# Altium schematic sheet Battery Charger.SchDoc
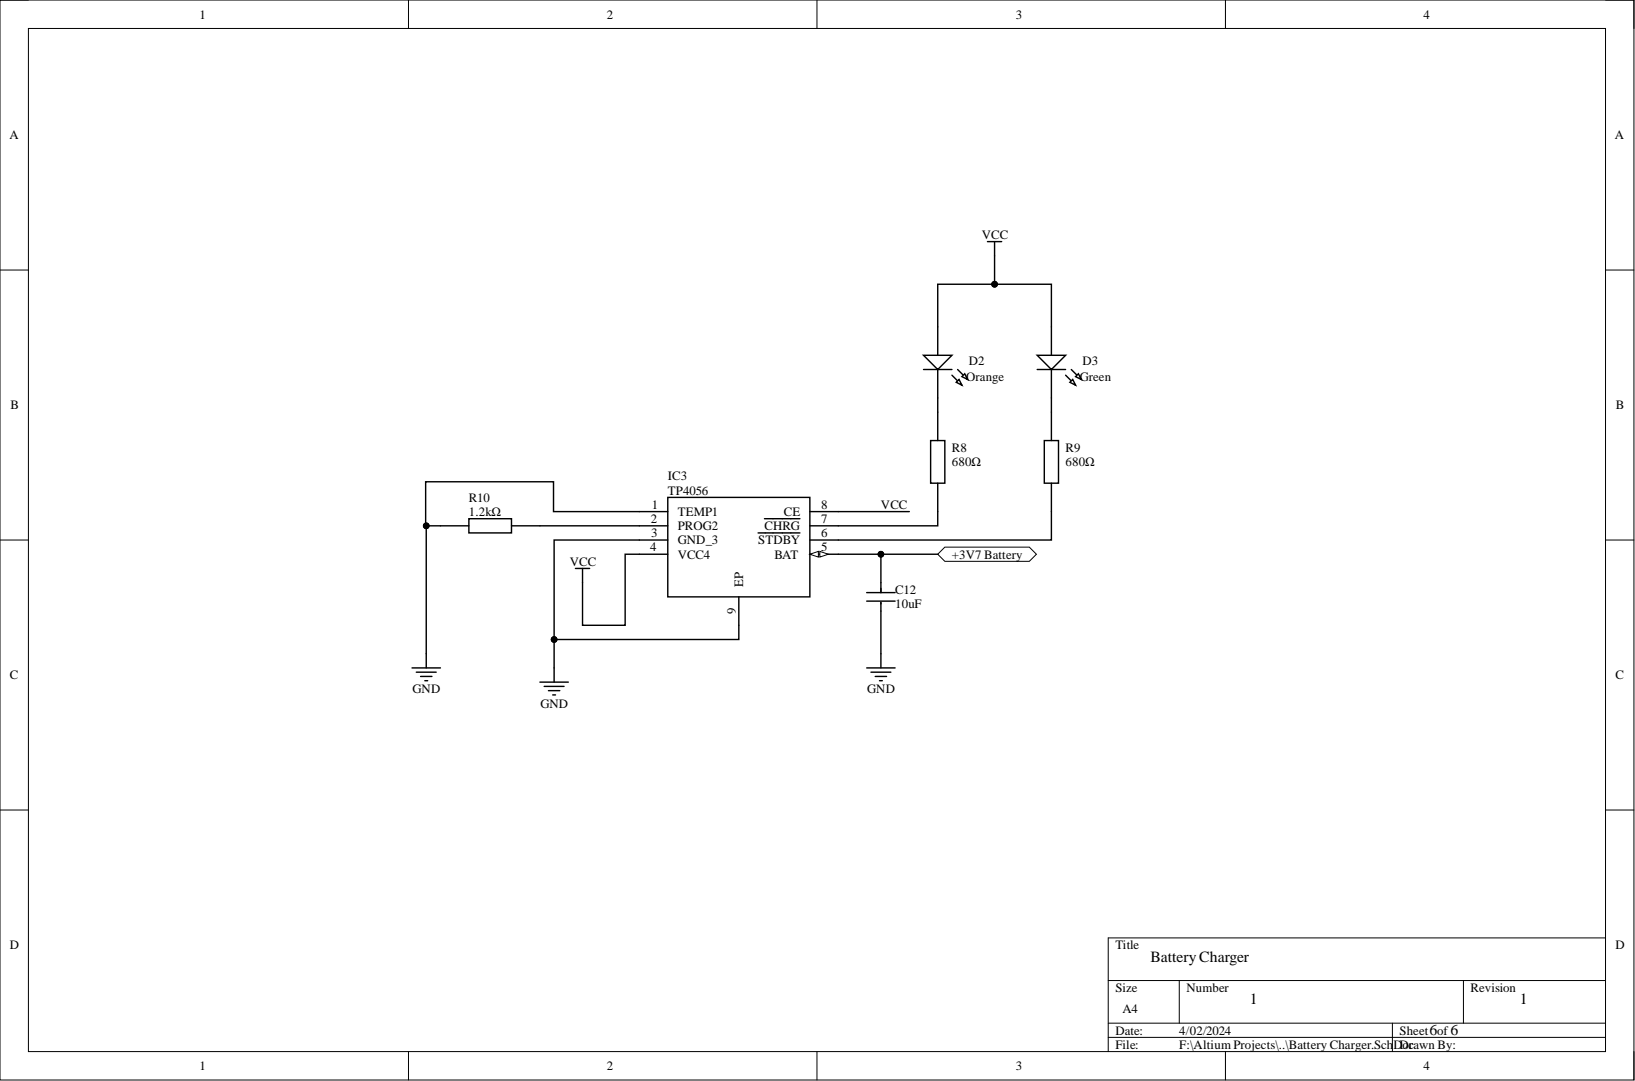
[diagram p0: Battery Charger.SchDoc]

## Parts
C12  Capacitance: 10uF  [FP-CL31-IPC_A]  (hidden comment: CL31F106ZPHNNNE)
D2  Color: Orange  [WL-SMCW_1206_150120xx75000]  (hidden comment: 150120AS75000)
D3  Color: Green  [WL-SMCW_1206_150120xx75000]  (hidden comment: 150120GS75000)
IC3  TP4056  [SOIC127P600X175-9N]
R8  value 680Ω  [RESC3116X65N]  (hidden comment: CRGH1206J680R)
R9  value 680Ω  [RESC3116X65N]  (hidden comment: CRGH1206J680R)
R10  value 1.2kΩ  [RESC3116X65N]  (hidden comment: CRCW12061K20FKEAHP)

## Ports
+3V7 Battery

## Nets
GND: C12.2 IC3.1(TEMP1) IC3.3(GND_3) IC3.9(EP) R10.1
+3V7 Battery: C12.1 IC3.5(BAT) ; port +3V7 Battery
VCC: D2.2 D3.2 IC3.4(VCC4) IC3.8(CE)
NetD2_1: D2.1 R8.2
NetD3_1: D3.1 R9.2
NetIC3_2: IC3.2(PROG2) R10.2
NetIC3_6: IC3.6(STDBY) R9.1
NetIC3_7: IC3.7(CHRG) R8.1

## Library data of the parts
C12 = CMP-13271-001127-1: Cap Ceramic 10uF 10V Y5V -20/+80% SMD 1206 +85°C Embossed T/R; Tolerance: 80%; Case Code (Imperial): 1206; Case Code (Metric): 3216; Case/Package: 1206; Component Kind: Standard; Depth: 1.6mm; Dielectric: Y5V; Height: 1.6mm; Lead Free: Lead Free; Length: 3.2mm; Library Name: Altium Content Vault; Max Operating Temperature: 85°C; Min Operating Temperature: -30°C; Mount: Surface Mount; Package Quantity: 1; Packaging: Tape and Reel; Radiation Hardening: No; Thickness: 0.071inch; Voltage Rating: 10V; Voltage Rating (DC): 10V; Width: 0.063inch
D2 = CMP-1426-00018-2: NexarPartId: 29717785; Dominant Wavelength: 605nm; Forward Current: 100mA; Forward Voltage: 2V; Lens Style: Clear, Rectangular; Luminous Intensity: 140mcd; Max Reverse Voltage (DC): 5V; Peak Wavelength: 610nm; Test Current: 20mA; Viewing Angle: 140°; Case Code (Imperial): 1206; Case Code (Metric): 3216; Case/Package: 1206; Component Kind: Standard; Height: 680µm; Length: 3.2mm; Library Name: Altium Content Vault; Max Operating Temperature: 85°C; Min Operating Temperature: -40°C; Mount: Surface Mount; Packaging: Tape and Reel; REACH SVHC: Unknown; RoHS: Compliant; Wavelength: 605nm; Width: 1.6mm
D3 = CMP-1426-00014-3: NexarPartId: 29717787; Dominant Wavelength: 525nm; Forward Current: 100mA; Forward Voltage: 3.2V; Lens Style: Clear, Rectangular; Luminous Intensity: 450mcd; Max Junction Temperature (Tj): 95°C; Max Reverse Voltage (DC): 5V; Peak Wavelength: 515nm; Power Dissipation: 105mW; Test Current: 20mA; Viewing Angle: 140°; Wavelength: 520nm; Case Code (Imperial): 1206; Case Code (Metric): 3216; Case/Package: 1206; Component Kind: Standard; Height: 680µm; Length: 3.2mm; Library Name: Altium Content Vault; Max Operating Temperature: 85°C; Min Operating Temperature: -40°C; Mount: Surface Mount; Packaging: Tape and Reel; REACH SVHC: Unknown; Reverse Voltage: 5V; RoHS: Compliant; Width: 1.6mm
IC3 = TP4056: Connector; Manufacturer_Name: NanJing Top Power; Component Kind: Standard; Datasheet Link: https://dlnmh9ip6v2uc.cloudfront.net/datasheets/Prototyping/TP4056.pdf; Height: 1.75mm; Manufacturer_Part_Number: TP4056
R8 R9 = CRGH1206J680R: Resistor; Manufacturer_Name: TE Connectivity; Component Kind: Standard; Datasheet Link: http://docs-europe.electrocomponents.com/webdocs/12d7/0900766b812d7379.pdf; Height: 0.65mm; Manufacturer_Part_Number: CRGH1206J680R; Mouser Part Number: 279-CRGH1206J680R; Mouser Price/Stock: https://www.mouser.com/Search/Refine.aspx?Keyword=279-CRGH1206J680R
R10 = CRCW12061K20FKEAHP: Resistor; Manufacturer_Name: Vishay; Arrow Part Number: CRCW12061K20FKEAHP; Arrow Price/Stock: https://www.arrow.com/en/products/crcw12061k20fkeahp/vishay; Component Kind: Standard; Datasheet Link: http://componentsearchengine.com/Datasheets/1/CRCW12061K20FKEAHP.pdf; Height: 0.65mm; Manufacturer_Part_Number: CRCW12061K20FKEAHP; Mouser Part Number: 71-CRCW12061K20FKEAH; Mouser Price/Stock: https://www.mouser.co.uk/ProductDetail/Vishay-Dale/CRCW12061K20FKEAHP?qs=k2%2FDWSARqgF5NgDWE9v3jw%3D%3D
